# Altium schematic sheet ATK-MCOV13850.SchDoc
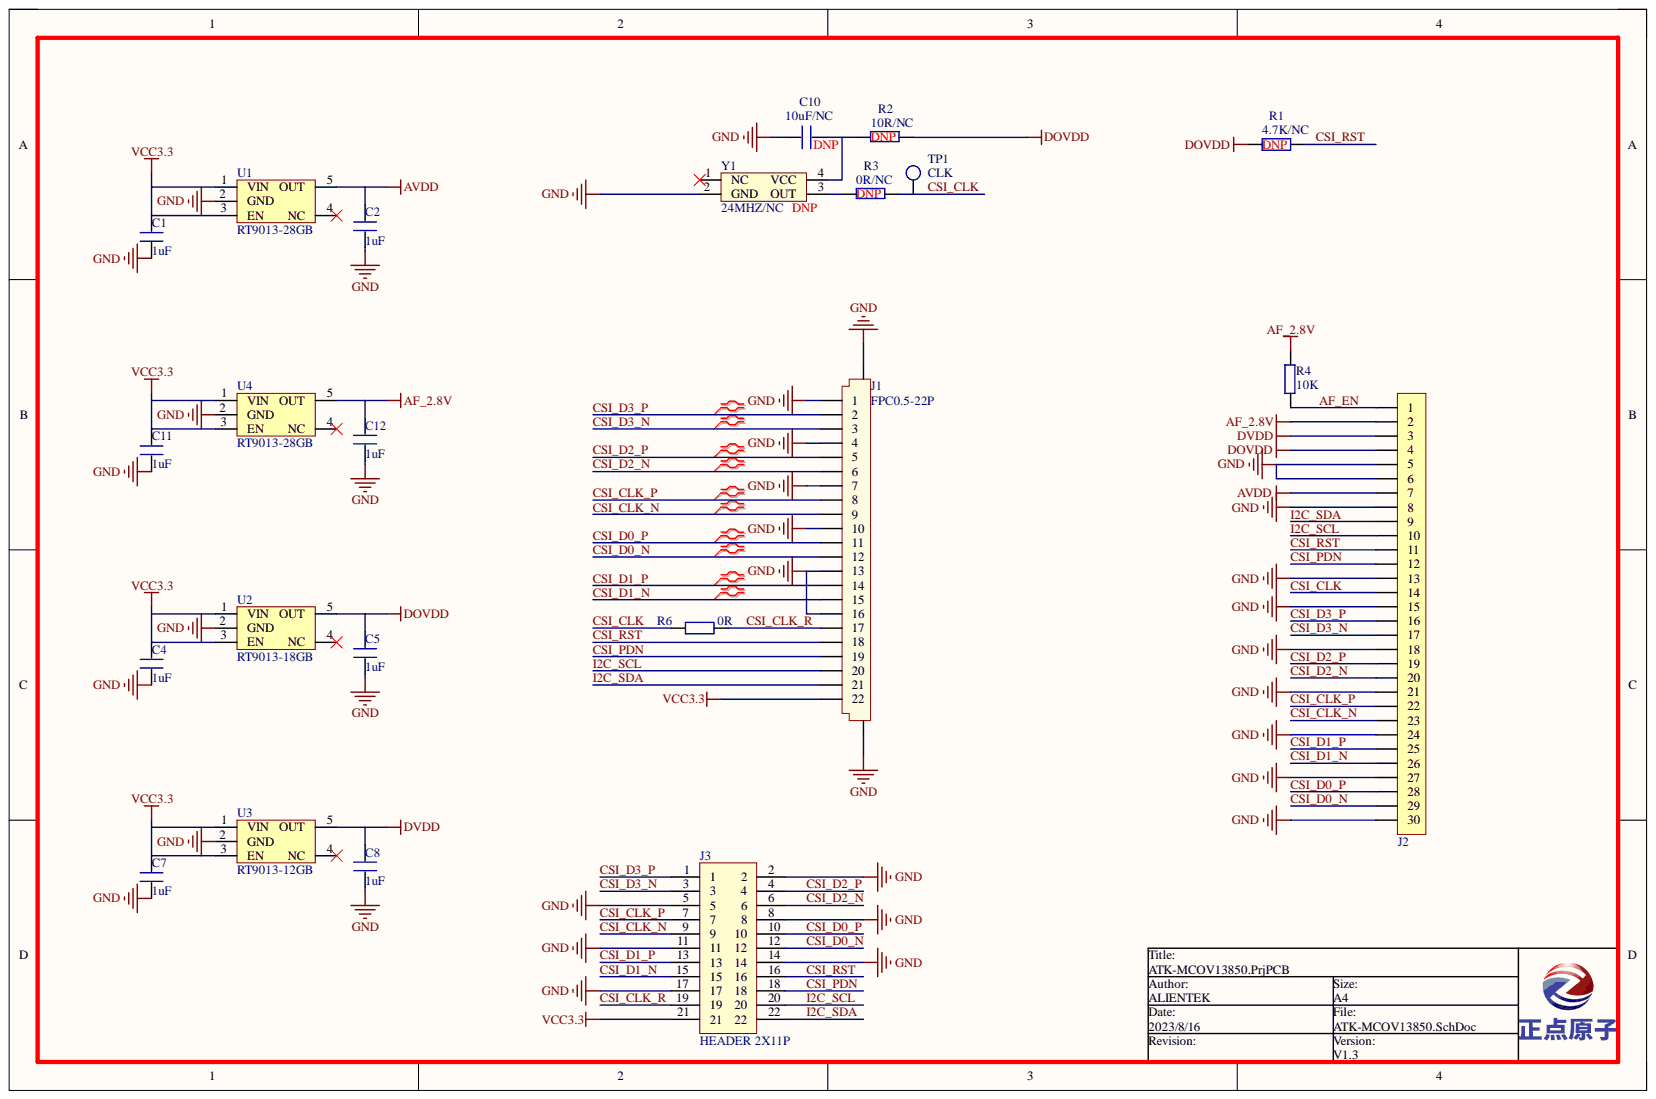
[diagram p0: ATK-MCOV13850.SchDoc]

## Parts
C1  1uF  [C 0603]
C2  1uF  [C 0603]
C4  1uF  [C 0603]
C5  1uF  [C 0603]
C7  1uF  [C 0603]
C8  1uF  [C 0603]
C10  10uF/NC  [C 0603]
C11  1uF  [C 0603]
C12  1uF  [C 0603]
J1  FPC0.5-22P  [FPC 0.5 22P H-S]
J2  FPC1.0-30P  [FPC0.5 2H-S-W-30P]  (value not drawn)
J3  HEADER 2X11P  [HEADER 2.0 2X11P]
R1  4.7K/NC  [R 0603]
R2  10R/NC  [R 0603]
R3  0R/NC  [R 0603]
R4  10K  [R 0603]
R6  0R  [R 0603]
TP1  CLK  [TP_0R70]
U1  RT9013-28GB  [SOT23-5N]
U2  RT9013-18GB  [SOT23-5N]
U3  RT9013-12GB  [SOT23-5N]
U4  RT9013-28GB  [SOT23-5N]
Y1  24MHZ/NC  [XTAL-3225 - S]

## Nets
AF_2.8V: C12.2 J2.2 R4.1 U4.5(OUT)
AVDD: C2.2 J2.7 U1.5(OUT)
DOVDD: C5.2 J2.4 R1.2 R2.1 U2.5(OUT)
DVDD: C8.2 J2.3 U3.5(OUT)
GND: C1.1 C2.1 C4.1 C5.1 C7.1 C8.1 C10.2 C11.1 C12.1 J1.0 J1.1 J1.4 J1.7 J1.10 J1.13 J1.16 J2.5 J2.6 J2.8 J2.13 J2.15 J2.18 J2.21 J2.24 J2.27 J2.30 J3.2 J3.5 J3.8 J3.11 J3.14 J3.17 U1.2(GND) U2.2(GND) U3.2(GND) U4.2(GND) Y1.2(GND)
VCC3.3: C1.2 C4.2 C7.2 C11.2 J1.22 J3.21 U1.1(VIN) U1.3(EN) U2.1(VIN) U2.3(EN) U3.1(VIN) U3.3(EN) U4.1(VIN) U4.3(EN)
AF_EN: J2.1 R4.2
CSI_CLK: J2.14 R3.1 R6.2 TP1.1
CSI_CLK_N: J1.9 J2.23 J3.9
CSI_CLK_P: J1.8 J2.22 J3.7
CSI_CLK_R: J1.17 J3.19 R6.1
CSI_D0_N: J1.12 J2.29 J3.12
CSI_D0_P: J1.11 J2.28 J3.10
CSI_D1_N: J1.15 J2.26 J3.15
CSI_D1_P: J1.14 J2.25 J3.13
CSI_D2_N: J1.6 J2.20 J3.6
CSI_D2_P: J1.5 J2.19 J3.4
CSI_D3_N: J1.3 J2.17 J3.3
CSI_D3_P: J1.2 J2.16 J3.1
CSI_PDN: J1.19 J2.12 J3.18
CSI_RST: J1.18 J2.11 J3.16 R1.1
I2C_SCL: J1.20 J2.10 J3.20
I2C_SDA: J1.21 J2.9 J3.22
NetC10_1: C10.1 R2.2 Y1.4(VCC)
NetR3_2: R3.2 Y1.3(OUT)

Not connected: U1 4(NC); U2 4(NC); U3 4(NC); U4 4(NC); Y1 1(NC)

## Library data of the parts
C1 C2 C4 C5 C7 C8 C10-C12 = C: Component Kind: Standard; Library Name: ALIENTEK_COMPONENT.IntLib
J1 = FPC-22P: FPC连接器; Parameter: 接口器件; 版权归属: 正点原子; BOM名称: FPC连接器; Component Kind: Standard; Library Name: 正点原子封装库V1.0.IntLib; 学习资源: www.openedv.com
J2 = FPC-30P: FPC连接器; Parameter: 接口器件; 版权归属: 正点原子; BOM名称: FPC连接器; Component Kind: Standard; 学习资源: www.openedv.com; Library Name: Lycreturn.IntLib
R1 R6 = R: 贴片电阻; Parameter: 电阻; 版权归属: 正点原子; BOM名称: 贴片电阻; Component Kind: Standard; 学习资源: www.openedv.com; Library Name: Lycreturn.IntLib
R2-R4 = R: Component Kind: Standard; Library Name: ALIENTEK_COMPONENT.IntLib
TP1 = TP: Test Point 0.5mm; Priority: A; RK PN: TESTPOINT_0R5; Component Kind: Standard; Part Type: TP; Created by: JJJ
U1-U4 = RT9013: LDO 5.5V 500mA; BOM名称: 稳压芯片; Parameter: 芯片; 版权归属: 正点原子; Component Kind: Standard; 学习资源: www.openedv.com; Library Name: Lycreturn.IntLib
Y1 = XTAL_S: 有源晶振; Component Kind: Standard; Library Name: ALIENTEK_COMPONENT.IntLib
J3 = HEADER 2X11P: Component Kind: Standard; Library Name: 新原理图库.SchLib
